# Altium schematic sheet Register board.SchDoc("Register board")
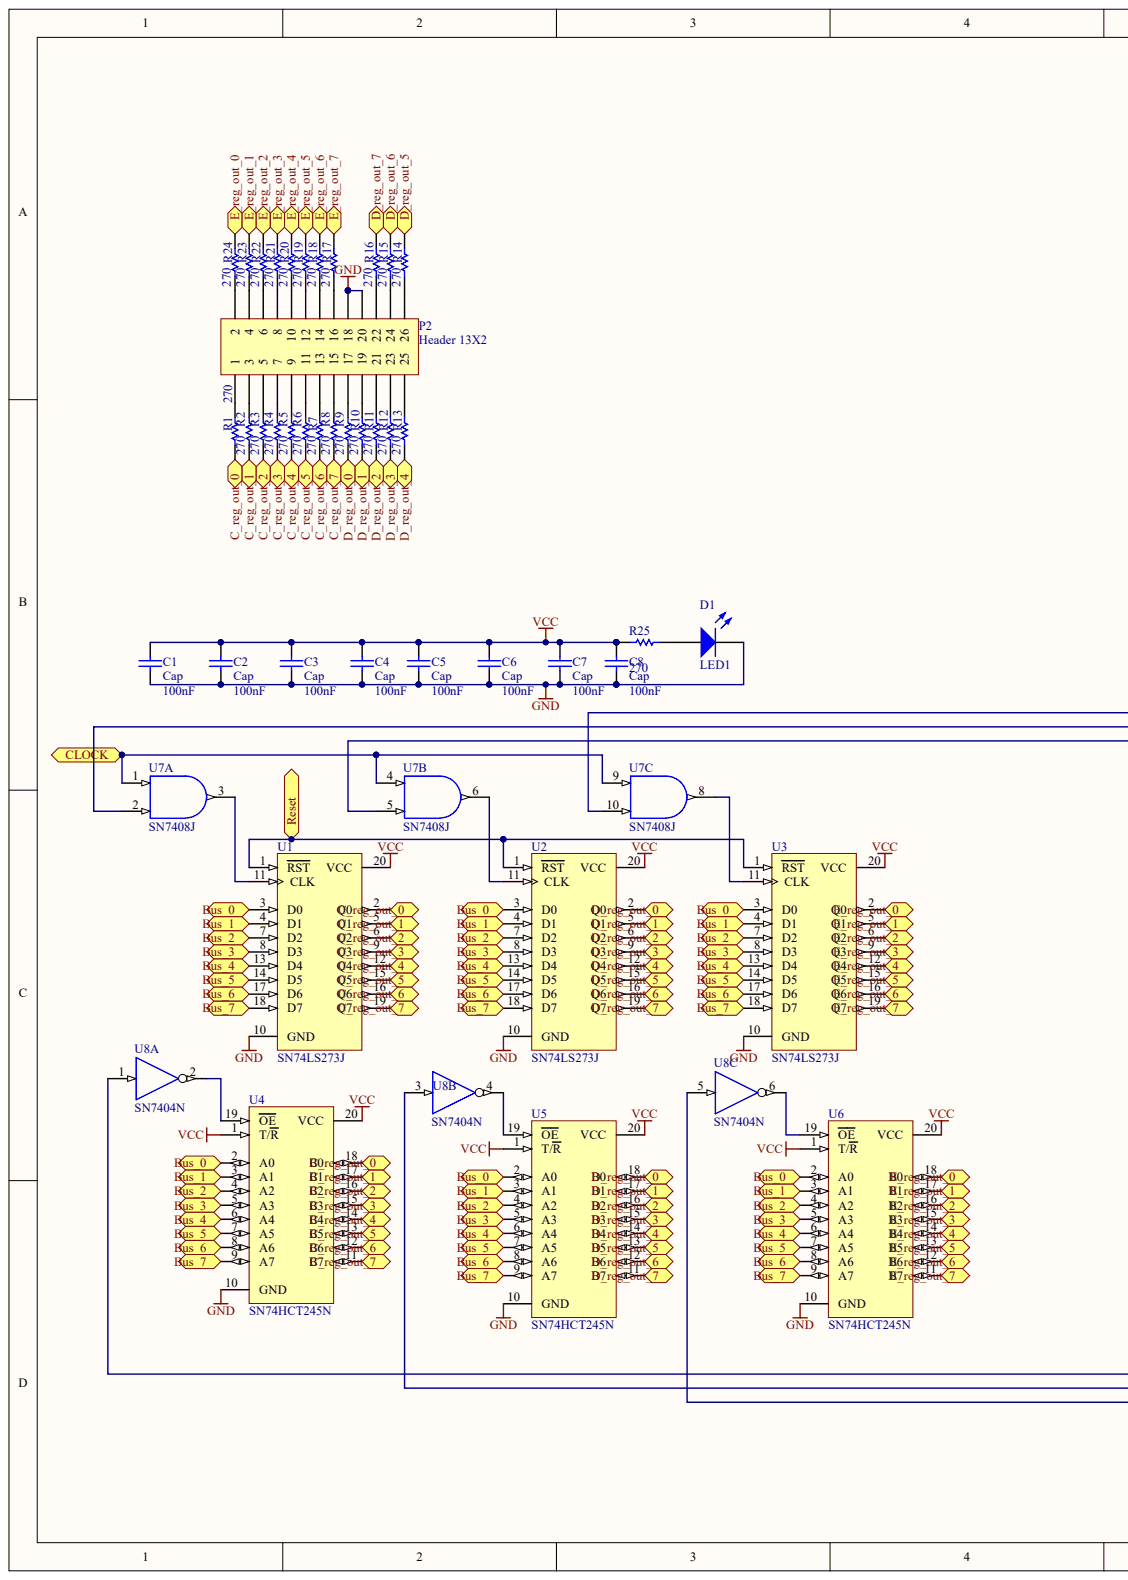
[diagram p0: Register board.SchDoc, left]
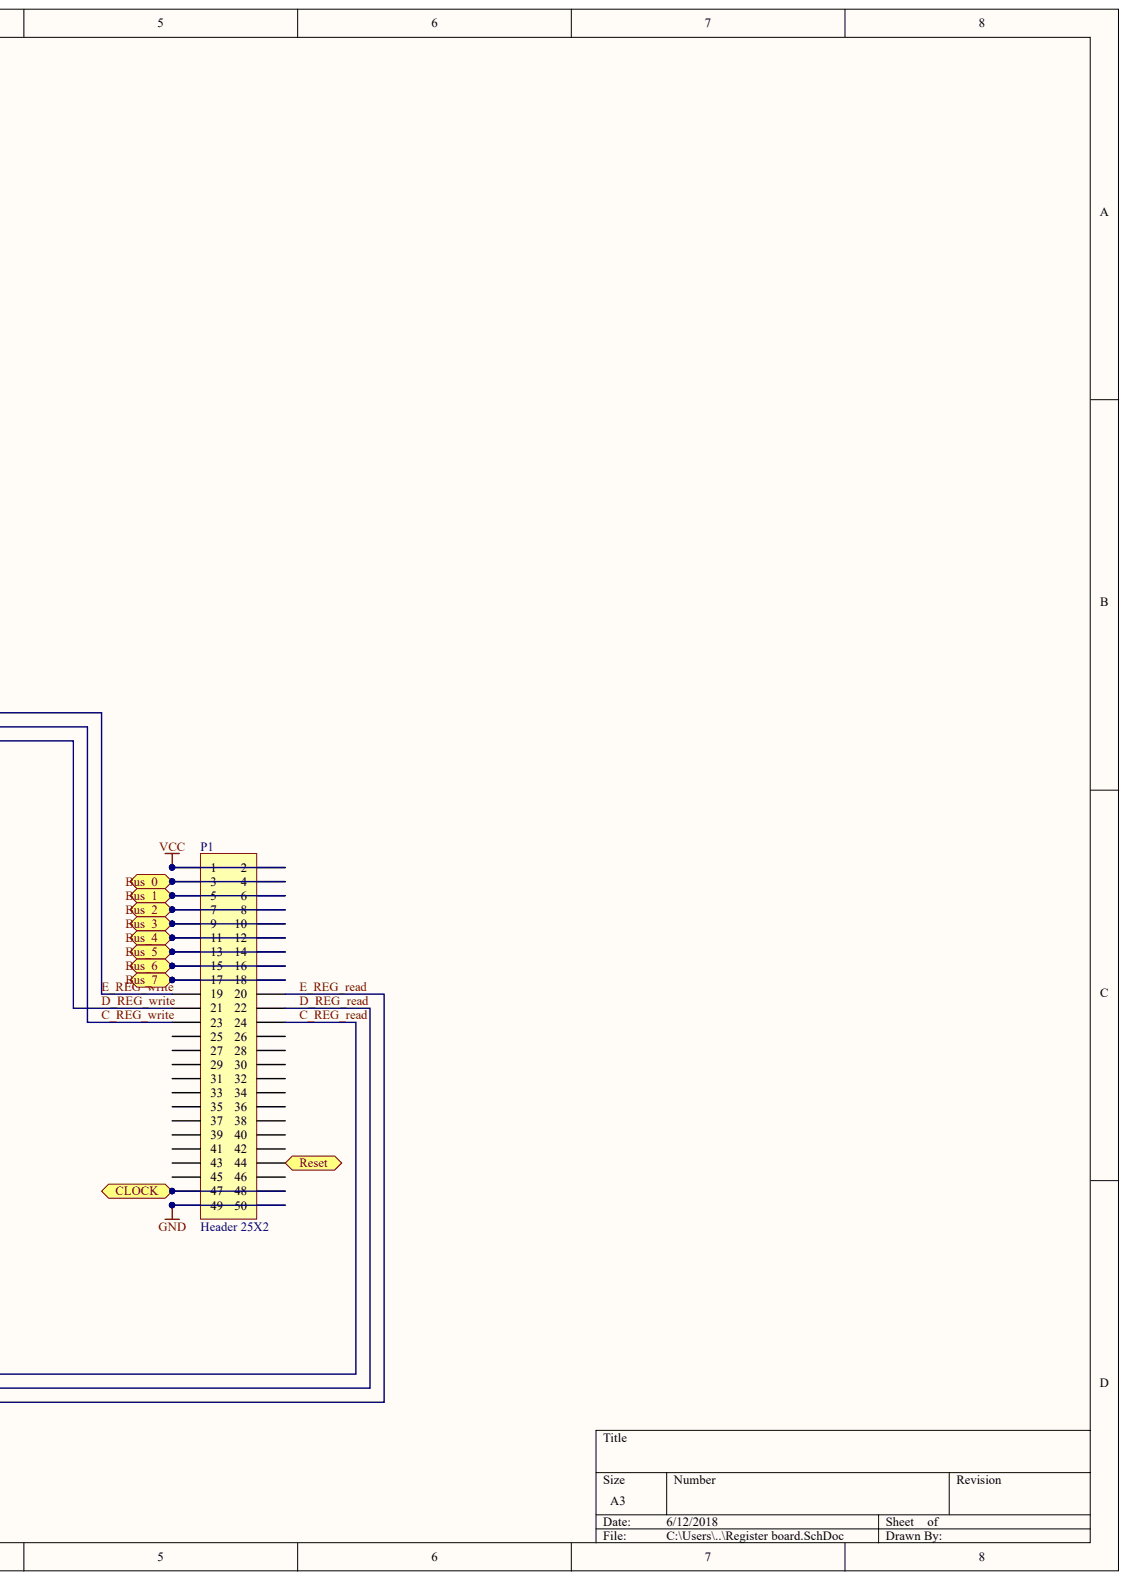
[diagram p1: Register board.SchDoc, right]

## Parts
C1  Cap  value 100nF
C2  Cap  value 100nF
C3  Cap  value 100nF
C4  Cap  value 100nF
C5  Cap  value 100nF
C6  Cap  value 100nF
C7  Cap  value 100nF
C8  Cap  value 100nF
D1  LED1
P1  Header 25X2
P2  Header 13X2
R1  value 270  (hidden comment: Res1)
R2  value 270  (hidden comment: Res1)
R3  value 270  (hidden comment: Res1)
R4  value 270  (hidden comment: Res1)
R5  value 270  (hidden comment: Res1)
R6  value 270  (hidden comment: Res1)
R7  value 270  (hidden comment: Res1)
R8  value 270  (hidden comment: Res1)
R9  value 270  (hidden comment: Res1)
R10  value 270  (hidden comment: Res1)
R11  value 270  (hidden comment: Res1)
R12  value 270  (hidden comment: Res1)
R13  value 270  (hidden comment: Res1)
R14  value 270  (hidden comment: Res1)
R15  value 270  (hidden comment: Res1)
R16  value 270  (hidden comment: Res1)
R17  value 270  (hidden comment: Res1)
R18  value 270  (hidden comment: Res1)
R19  value 270  (hidden comment: Res1)
R20  value 270  (hidden comment: Res1)
R21  value 270  (hidden comment: Res1)
R22  value 270  (hidden comment: Res1)
R23  value 270  (hidden comment: Res1)
R24  value 270  (hidden comment: Res1)
R25  value 270  (hidden comment: Res1)
U1  SN74LS273J
U2  SN74LS273J
U3  SN74LS273J
U4  SN74HCT245N
U5  SN74HCT245N
U6  SN74HCT245N
U7  SN7408J  (parts A,B,C)
U8  SN7404N  (parts A,B,C)

## Ports
BUS_0, BUS_1, BUS_2, BUS_3, BUS_4, BUS_5, BUS_6, BUS_7, C_REG_OUT_0, C_REG_OUT_1, C_REG_OUT_2, C_REG_OUT_3, C_REG_OUT_4, C_REG_OUT_5, C_REG_OUT_6, C_REG_OUT_7, CLOCK, D_REG_OUT_0, D_REG_OUT_1, D_REG_OUT_2, D_REG_OUT_3, D_REG_OUT_4, D_REG_OUT_5, D_REG_OUT_6, D_REG_OUT_7, E_REG_OUT_0, E_REG_OUT_1, E_REG_OUT_2, E_REG_OUT_3, E_REG_OUT_4, E_REG_OUT_5, E_REG_OUT_6, E_REG_OUT_7, RESET

## Nets
GND: C1.1 C2.1 C3.1 C4.1 C5.1 C6.1 C7.1 C8.1 D1.2 P1.49 P1.50 P2.18 P2.20 U1.10(GND) U2.10(GND) U3.10(GND) U4.10(GND) U5.10(GND) U6.10(GND) U7.7 U8.7
VCC: C1.2 C2.2 C3.2 C4.2 C5.2 C6.2 C7.2 C8.2 P1.1 P1.2 R25.1 U1.20(VCC) U2.20(VCC) U3.20(VCC) U4.1(T/R) U4.20(VCC) U5.1(T/R) U5.20(VCC) U6.1(T/R) U6.20(VCC) U7.14 U8.14
C_REG_READ: P1.24 U8.1
C_REG_WRITE: P1.23 U7.2
CLOCK: P1.47 P1.48 U7.1 U7.4 U7.9 ; port CLOCK
D_REG_READ: P1.22 U8.3
D_REG_WRITE: P1.21 U7.5
E_REG_READ: P1.20 U8.5
E_REG_WRITE: P1.19 U7.10
NetD1_1: D1.1 R25.2
NetP1_3: P1.3 P1.4 U1.3(D0) U2.3(D0) U3.3(D0) U4.2(A0) U5.2(A0) U6.2(A0) ; port BUS_0
NetP1_5: P1.5 P1.6 U1.4(D1) U2.4(D1) U3.4(D1) U4.3(A1) U5.3(A1) U6.3(A1) ; port BUS_1
NetP1_7: P1.7 P1.8 U1.7(D2) U2.7(D2) U3.7(D2) U4.4(A2) U5.4(A2) U6.4(A2) ; port BUS_2
NetP1_9: P1.9 P1.10 U1.8(D3) U2.8(D3) U3.8(D3) U4.5(A3) U5.5(A3) U6.5(A3) ; port BUS_3
NetP1_11: P1.11 P1.12 U1.13(D4) U2.13(D4) U3.13(D4) U4.6(A4) U5.6(A4) U6.6(A4) ; port BUS_4
NetP1_13: P1.13 P1.14 U1.14(D5) U2.14(D5) U3.14(D5) U4.7(A5) U5.7(A5) U6.7(A5) ; port BUS_5
NetP1_15: P1.15 P1.16 U1.17(D6) U2.17(D6) U3.17(D6) U4.8(A6) U5.8(A6) U6.8(A6) ; port BUS_6
NetP1_17: P1.17 P1.18 U1.18(D7) U2.18(D7) U3.18(D7) U4.9(A7) U5.9(A7) U6.9(A7) ; port BUS_7
NetP1_44: P1.44 U1.1(RST) U2.1(RST) U3.1(RST) ; port RESET
NetP2_1: P2.1 R1.2
NetP2_2: P2.2 R24.2
NetP2_3: P2.3 R2.2
NetP2_4: P2.4 R23.2
NetP2_5: P2.5 R3.2
NetP2_6: P2.6 R22.2
NetP2_7: P2.7 R4.2
NetP2_8: P2.8 R21.2
NetP2_9: P2.9 R5.2
NetP2_10: P2.10 R20.2
NetP2_11: P2.11 R6.2
NetP2_12: P2.12 R19.2
NetP2_13: P2.13 R7.2
NetP2_14: P2.14 R18.2
NetP2_15: P2.15 R8.2
NetP2_16: P2.16 R17.2
NetP2_17: P2.17 R9.2
NetP2_19: P2.19 R10.2
NetP2_21: P2.21 R11.2
NetP2_22: P2.22 R16.1
NetP2_23: P2.23 R12.2
NetP2_24: P2.24 R15.1
NetP2_25: P2.25 R13.2
NetP2_26: P2.26 R14.1
NetR1_1: R1.1 U1.2(Q0) U4.18(B0) ; port C_REG_OUT_0
NetR2_1: R2.1 U1.5(Q1) U4.17(B1) ; port C_REG_OUT_1
NetR3_1: R3.1 U1.6(Q2) U4.16(B2) ; port C_REG_OUT_2
NetR4_1: R4.1 U1.9(Q3) U4.15(B3) ; port C_REG_OUT_3
NetR5_1: R5.1 U1.12(Q4) U4.14(B4) ; port C_REG_OUT_4
NetR6_1: R6.1 U1.15(Q5) U4.13(B5) ; port C_REG_OUT_5
NetR7_1: R7.1 U1.16(Q6) U4.12(B6) ; port C_REG_OUT_6
NetR8_1: R8.1 U1.19(Q7) U4.11(B7) ; port C_REG_OUT_7
NetR9_1: R9.1 U2.2(Q0) U5.18(B0) ; port D_REG_OUT_0
NetR10_1: R10.1 U2.5(Q1) U5.17(B1) ; port D_REG_OUT_1
NetR11_1: R11.1 U2.6(Q2) U5.16(B2) ; port D_REG_OUT_2
NetR12_1: R12.1 U2.9(Q3) U5.15(B3) ; port D_REG_OUT_3
NetR13_1: R13.1 U2.12(Q4) U5.14(B4) ; port D_REG_OUT_4
NetR14_2: R14.2 U2.15(Q5) U5.13(B5) ; port D_REG_OUT_5
NetR15_2: R15.2 U2.16(Q6) U5.12(B6) ; port D_REG_OUT_6
NetR16_2: R16.2 U2.19(Q7) U5.11(B7) ; port D_REG_OUT_7
NetR17_1: R17.1 U3.19(Q7) U6.11(B7) ; port E_REG_OUT_7
NetR18_1: R18.1 U3.16(Q6) U6.12(B6) ; port E_REG_OUT_6
NetR19_1: R19.1 U3.15(Q5) U6.13(B5) ; port E_REG_OUT_5
NetR20_1: R20.1 U3.12(Q4) U6.14(B4) ; port E_REG_OUT_4
NetR21_1: R21.1 U3.9(Q3) U6.15(B3) ; port E_REG_OUT_3
NetR22_1: R22.1 U3.6(Q2) U6.16(B2) ; port E_REG_OUT_2
NetR23_1: R23.1 U3.5(Q1) U6.17(B1) ; port E_REG_OUT_1
NetR24_1: R24.1 U3.2(Q0) U6.18(B0) ; port E_REG_OUT_0
NetU1_11: U1.11(CLK) U7.3
NetU2_11: U2.11(CLK) U7.6
NetU3_11: U3.11(CLK) U7.8
NetU4_19: U4.19(OE) U8.2
NetU5_19: U5.19(OE) U8.4
NetU6_19: U6.19(OE) U8.6

Not connected: P1 25 26 27 28 29 30 31 32 33 34 35 36 37 38 39 40 41 42 43 45 46

## Library data of the parts
R25 = (no symbol name): Value: 270; Published: 8-Jun-2000; LatestRevisionDate: 17-Jul-2002; LatestRevisionNote: Re-released for DXP Platform.; PackageReference: AXIAL-0.3; PackageDescription: Axial Device, Thru-Hole; 2 Leads; 0.3 in Pin Spacing; Publisher: Altium Limited
U1-U3 = (no symbol name): ComponentAvailability: Obsolete; Published: 8-Jun-2000; LatestRevisionDate: 04-Mar-2005; LatestRevisionNote: Stylized 3D Model Added.; DatasheetVersion: Mar-1988; PackageVersion: Jun-1999; PackageReference: J020; PackageDescription: DIP; 20 Leads; Row Spacing 7.62 mm; Pitch 2.54 mm; ComponentLink1Description: Manufacturer Link; ComponentLink1URL: http://www.ti.com/; ComponentLink2Description: Datasheet; ComponentLink2URL: http://www-s.ti.com/sc/ds/sn74273.pdf; ComponentLink3Description: Discontinuation Notice; ComponentLink3URL: http://focus.ti.com/docs/prod/folders/print/sn74ls273.html; Publisher: Altium Limited
C1-C8 D1 P1 P2 R1-R24 U4-U8 = (no symbol name): Publisher: Altium Limited
  C1-C8: Published: 8-Jun-2000; LatestRevisionDate: 17-Jul-2002; LatestRevisionNote: Re-released for DXP Platform.; PackageReference: RAD-0.3; PackageDescription: Radial Cap, Thru-Hole; 2 Leads; 0.3 in Pin Spacing
  D1: Published: 8-Jun-2000; LatestRevisionDate: 08-Jul-2005; LatestRevisionNote: Mech Layer 1 removed.; PackageReference: LED-1; PackageDescription: LED; 2 Leads
  P1 P2: LatestRevisionDate: 17-Jul-2002; LatestRevisionNote: Re-released for DXP Platform.
  R1-R24: Published: 8-Jun-2000; LatestRevisionDate: 17-Jul-2002; LatestRevisionNote: Re-released for DXP Platform.; PackageReference: AXIAL-0.3; PackageDescription: Axial Device, Thru-Hole; 2 Leads; 0.3 in Pin Spacing
  U4-U6: Published: 8-Jun-2000; LatestRevisionDate: 04-Mar-2005; LatestRevisionNote: Stylized 3D Model Added.; DatasheetVersion: May-1997; PackageVersion: Oct-1995; PackageReference: N020; PackageDescription: DIP; 20 Leads; Row Spacing 7.62 mm; Pitch 2.54 mm; ComponentLink1Description: Manufacturer Link; ComponentLink1URL: http://www.ti.com/; ComponentLink2Description: Datasheet; ComponentLink2URL: http://www-s.ti.com/sc/ds/sn74hct245.pdf
  U7: Published: 8-Jun-2000; LatestRevisionDate: 04-Mar-2005; LatestRevisionNote: Stylized 3D Model Added.; DatasheetVersion: Mar-1988; PackageVersion: Jun-1999; PackageReference: J014; PackageDescription: DIP; 14 Leads; Row Spacing 7.62 mm; Pitch 2.54 mm; ComponentLink1Description: Manufacturer Link; ComponentLink1URL: http://www.ti.com/; ComponentLink2Description: Datasheet; ComponentLink2URL: http://www-s.ti.com/sc/ds/sn54ls08.pdf; ComponentLink3Description: Packaging Specification; ComponentLink3URL: http://focus.ti.com/lit/ml/mcer002d/mcer002d.pdf
  U8: Published: 8-Jun-2000; LatestRevisionDate: 04-Mar-2005; LatestRevisionNote: Stylized 3D Model Added.; DatasheetVersion: Mar-1988; PackageVersion: Oct-1995; PackageReference: N014; PackageDescription: DIP; 14 Leads; Row Spacing 7.62 mm; Pitch 2.54 mm; ComponentLink1Description: Manufacturer Link; ComponentLink1URL: http://www.ti.com/; ComponentLink2Description: Datasheet; ComponentLink2URL: http://www-s.ti.com/sc/ds/sn5404.pdf; ComponentLink3Description: Packaging Specification; ComponentLink3URL: http://focus.ti.com/lit/ml/mpdi002c/mpdi002c.pdf
